# Altium schematic sheet ETH_PHY_LAN8720a_V1906.sch
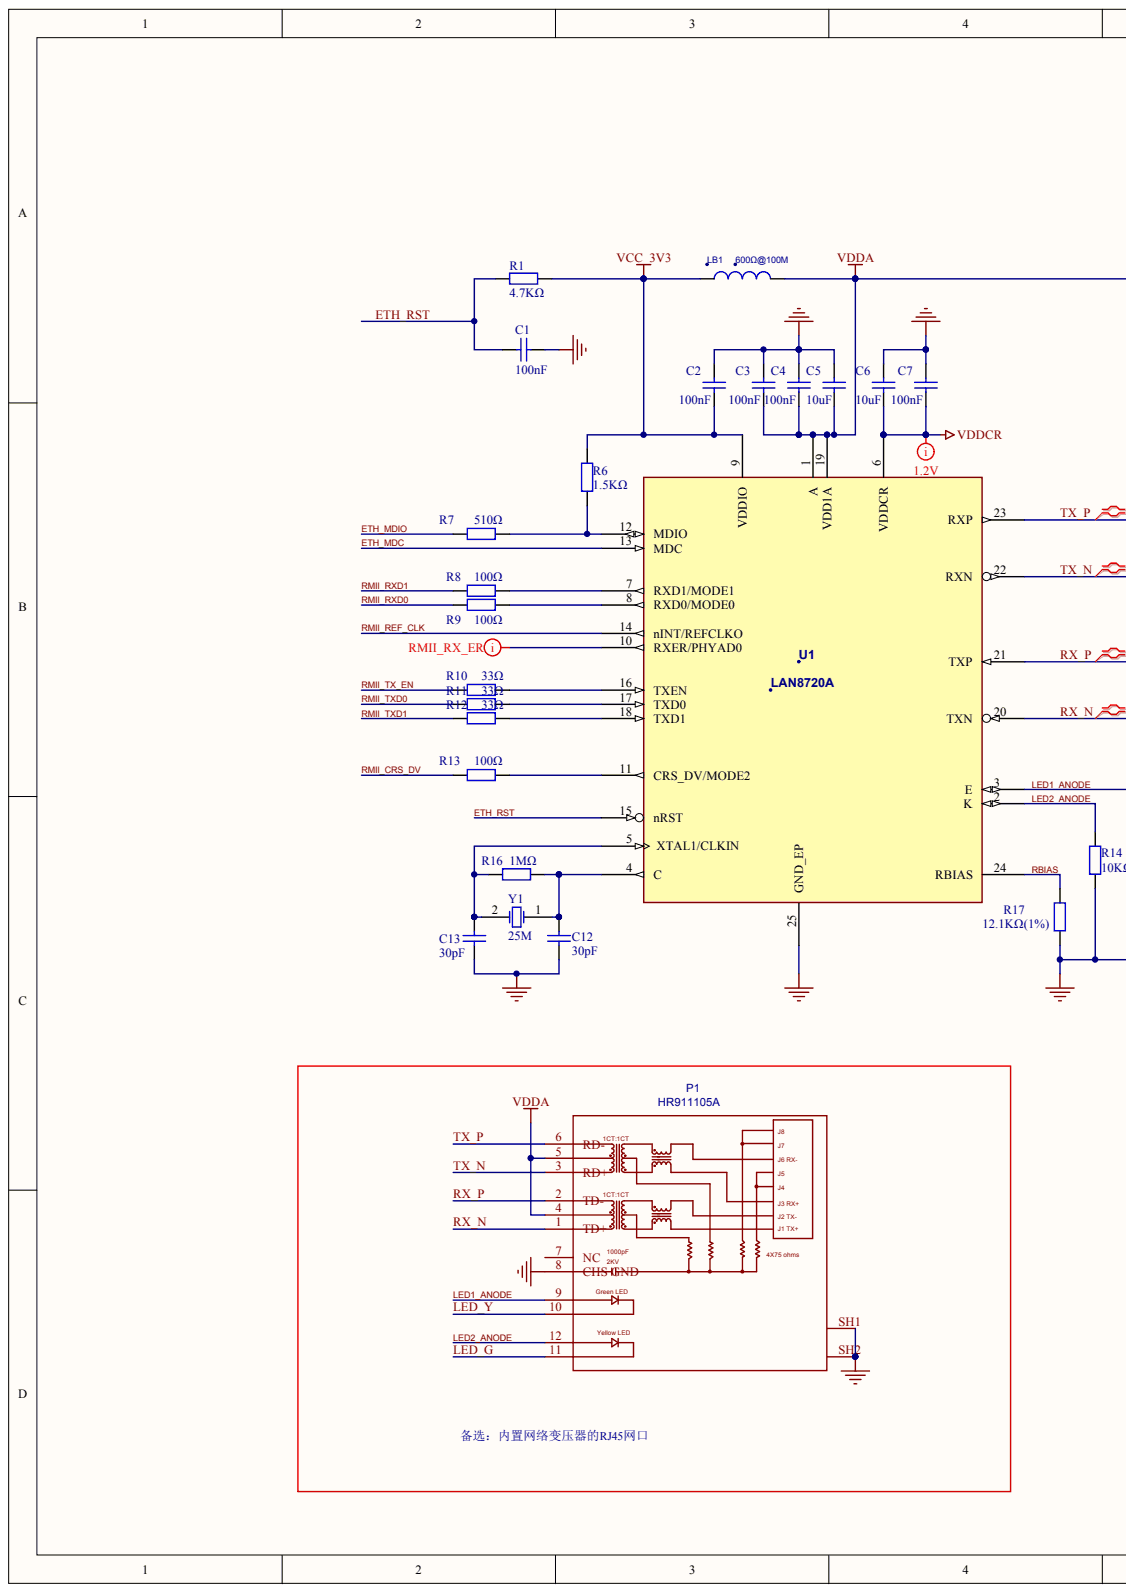
[diagram p0: ETH_PHY_LAN8720a_V1906.sch, left]
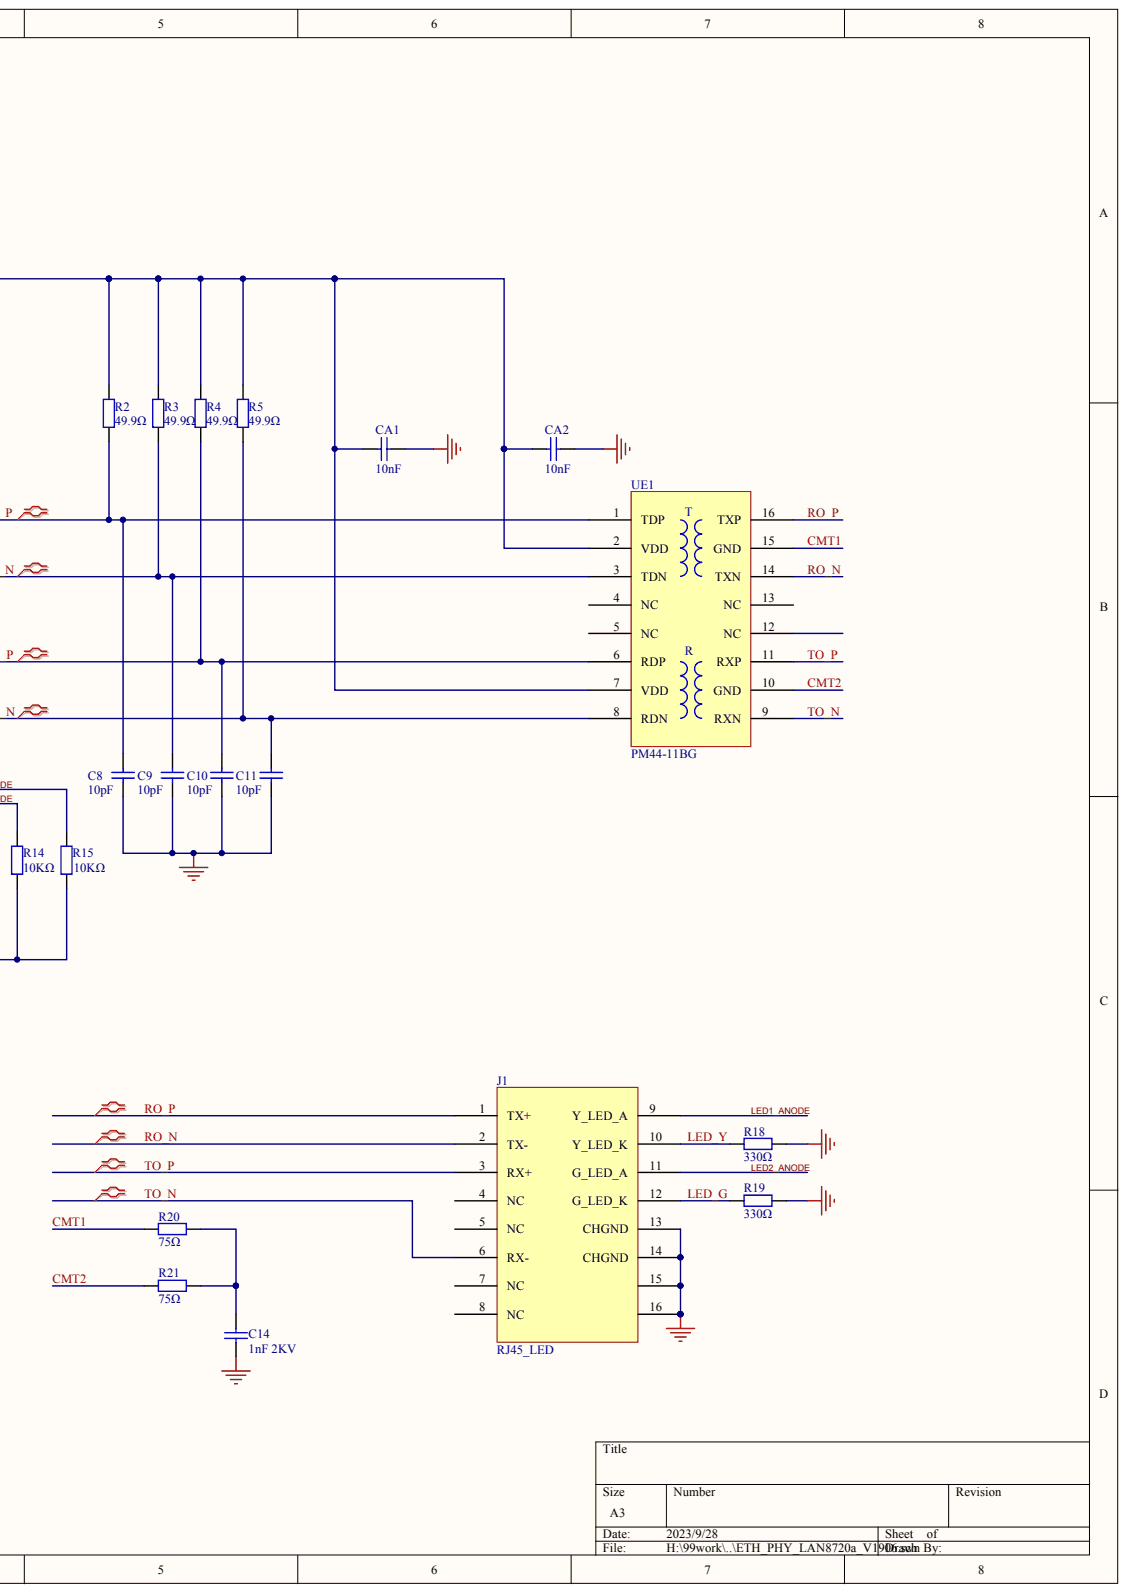
[diagram p1: ETH_PHY_LAN8720a_V1906.sch, right]

## Parts
C1  100nF
C2  100nF
C3  100nF
C4  100nF
C5  10uF
C6  10uF
C7  100nF
C8  10pF
C9  10pF
C10  10pF
C11  10pF
C12  30pF
C13  30pF
C14  1nF 2KV
CA1  10nF
CA2  10nF
J1  RJ45_LED
LB1  600Ω@100M
P1  HR911105A
R1  4.7KΩ
R2  49.9Ω
R3  49.9Ω
R4  49.9Ω
R5  49.9Ω
R6  1.5KΩ
R7  510Ω
R8  100Ω
R9  100Ω
R10  33Ω
R11  33Ω
R12  33Ω
R13  100Ω
R14  10KΩ
R15  10KΩ
R16  1MΩ
R17  12.1KΩ(1%)
R18  330Ω
R19  330Ω
R20  75Ω
R21  75Ω
U1  LAN8720A
UE1  PM44-11BG
Y1  25M

## Nets
GND: C1.1 C2.1 C3.1 C4.1 C5.1 C6.1 C7.1 C8.2 C9.2 C10.2 C11.2 C12.2 C13.2 C14.1 CA1.2 CA2.2 J1.13(CHGND) J1.14(CHGND) J1.15 J1.16 P1.8(CHS_GND) P1.SH1 P1.SH2 R14.2 R15.2 R17.2 R18.2 R19.2 U1.25(GND_EP)
VCC_3V3: C2.2 LB1.1 R1.2 R6.1 U1.9(VDDIO)
VDDA: C3.2 C4.2 C5.2 CA1.1 CA2.1 LB1.2 P1.4 P1.5 R2.1 R3.1 R4.1 R5.1 U1.1(A) U1.19(VDD1A) UE1.2(VDD) UE1.7(VDD)
VDDCR: C6.2 C7.2 U1.6(VDDCR)
CMT1: R20.1 UE1.15(GND)
CMT2: R21.1 UE1.10(GND)
ETH_MDC: U1.13(MDC)
ETH_MDIO: R7.2
ETH_RST: C1.2 R1.1 U1.15(nRST)
LED1_ANODE: J1.9(Y_LED_A) P1.9 R15.1 U1.3(E)
LED2_ANODE: J1.11(G_LED_A) P1.12 R14.1 U1.2(K)
LED_G: J1.12(G_LED_K) P1.11 R19.1
LED_Y: J1.10(Y_LED_K) P1.10 R18.1
RBIAS: R17.1 U1.24(RBIAS)
RMII_CRS_DV: R13.2
RMII_REF_CLK: U1.14(nINT/REFCLKO)
RMII_RXD0: R9.2
RMII_RXD1: R8.2
RMII_TX_EN: R10.2
RMII_TXD0: R11.2
RMII_TXD1: R12.2
RO_N: J1.2(TX-) UE1.14(TXN)
RO_P: J1.1(TX+) UE1.16(TXP)
RX_N: C11.1 P1.1(TD+) R5.2 U1.20(TXN) UE1.8(RDN)
RX_P: C10.1 P1.2(TD-) R4.2 U1.21(TXP) UE1.6(RDP)
TO_N: J1.6(RX-) UE1.9(RXN)
TO_P: J1.3(RX+) UE1.11(RXP)
TX_N: C9.1 P1.3(RD+) R3.2 U1.22(RXN) UE1.3(TDN)
TX_P: C8.1 P1.6(RD-) R2.2 U1.23(RXP) UE1.1(TDP)
NetC12_1: C12.1 R16.1 U1.4(C) Y1.1
NetC13_1: C13.1 R16.2 U1.5(XTAL1/CLKIN) Y1.2
NetC14_2: C14.2 R20.2 R21.2
NetR6_2: R6.2 R7.1 U1.12(MDIO)
NetR8_1: R8.1 U1.7(RXD1/MODE1)
NetR9_1: R9.1 U1.8(RXD0/MODE0)
NetR10_1: R10.1 U1.16(TXEN)
NetR11_1: R11.1 U1.17(TXD0)
NetR12_1: R12.1 U1.18(TXD1)
NetR13_1: R13.1 U1.11(CRS_DV/MODE2)
N00137:

Not connected: J1 4(NC) 5(NC) 7(NC) 8(NC); P1 7(NC); U1 10(RXER/PHYAD0); UE1 4(NC) 5(NC) 12(NC) 13(NC)

## Library data of the parts
LB1 = (no symbol name): PART#: Murata BLM18PG121SN1D; RoHS: RoHS
P1 = (no symbol name): BOM_Manufacturer: HANRUN(汉仁); BOM_Supplier: LCSC; BOM_Manufacturer Part: HR911105A; BOM_Supplier Part: C12074; BOM_JLCPCB Part Class: Extended Part; spicePre: J; spiceSymbolName: HR911105A_C12074
U1 = (no symbol name): PART#: SMSC LAN8720A-CP
C1-C14 CA1 CA2 J1 R1-R21 UE1 Y1 = (no symbol name)
  C1-C13 CA1 CA2: ComponentLink1Description: 供应商链接; ComponentLink1URL: http://www.szlcsc.com/product/details_15331.html; Package: 0603; Supplier: LC; SuppliersPartNumber: C14663
  C14: ComponentLink1Description: 供应商链接; ComponentLink1URL: http://www.szlcsc.com/product/details_2198.html; Package: 1206; Supplier: LC; SuppliersPartNumber: C1846
  R1-R21: ComponentLink1Description: 供应商链接; ComponentLink1URL: http://www.szlcsc.com/product/details_21904.html; Package: 0603; Supplier: LC; SuppliersPartNumber: C21190
  Y1: Published: 8-Jun-2000; LatestRevisionDate: 17-Jul-2002; LatestRevisionNote: Re-released for DXP Platform.; PackageReference: R38; PackageDescription: Crystal, Thru-Hole; 2 Leads; Body 3.1 x 8.2 mm (Dia.xH); Publisher: Altium Limited
